# Altium schematic sheet 热网系统_采样板.SchDoc(热网系统_采样板)
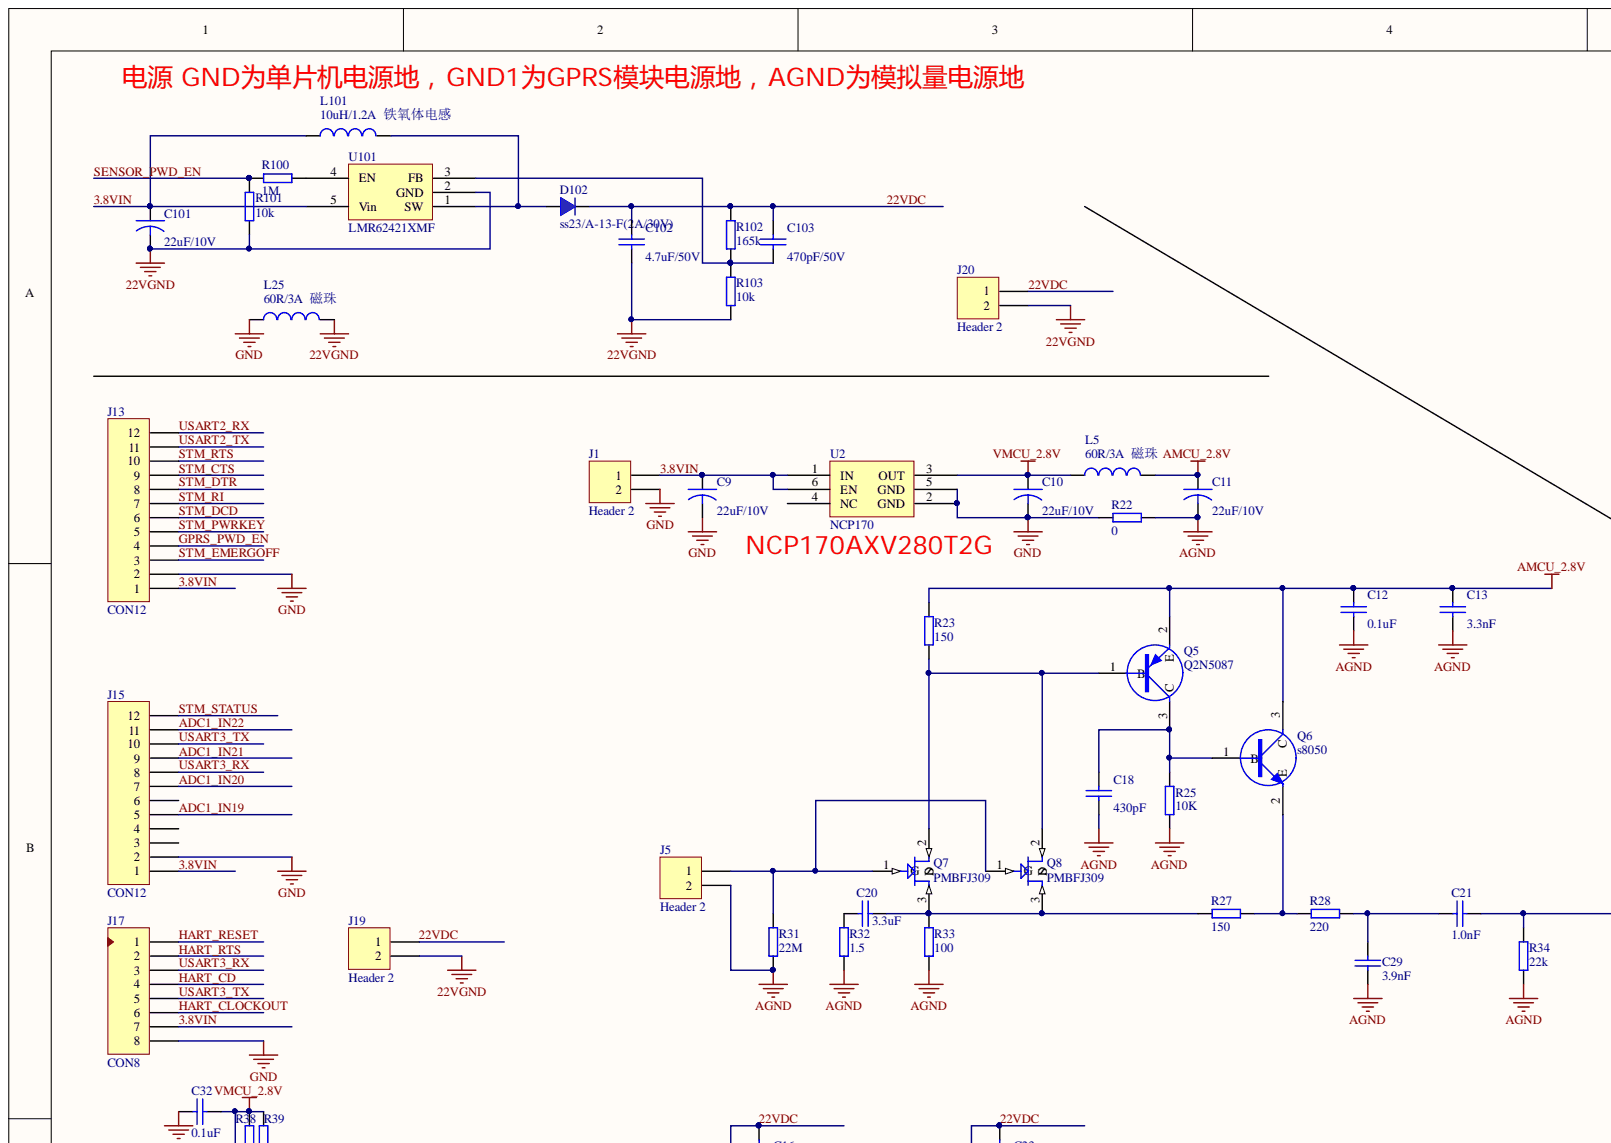
[diagram p0: 热网系统_采样板.SchDoc, top left]
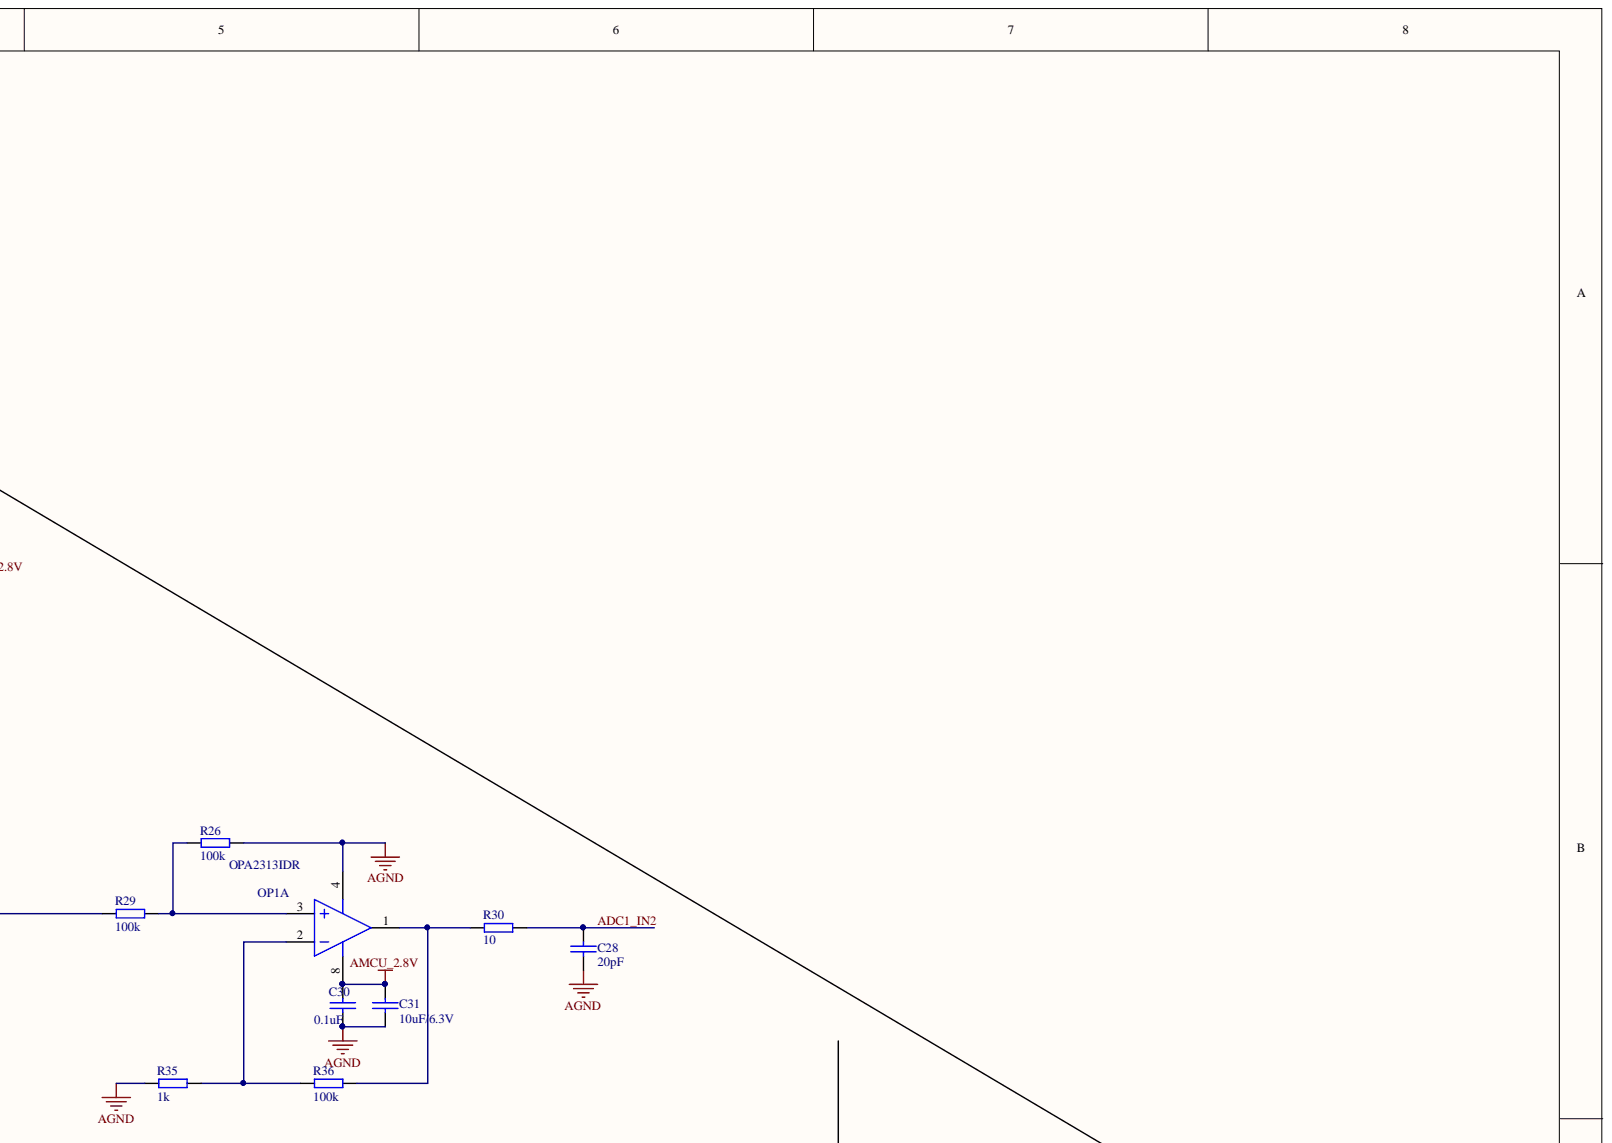
[diagram p1: 热网系统_采样板.SchDoc, top right]
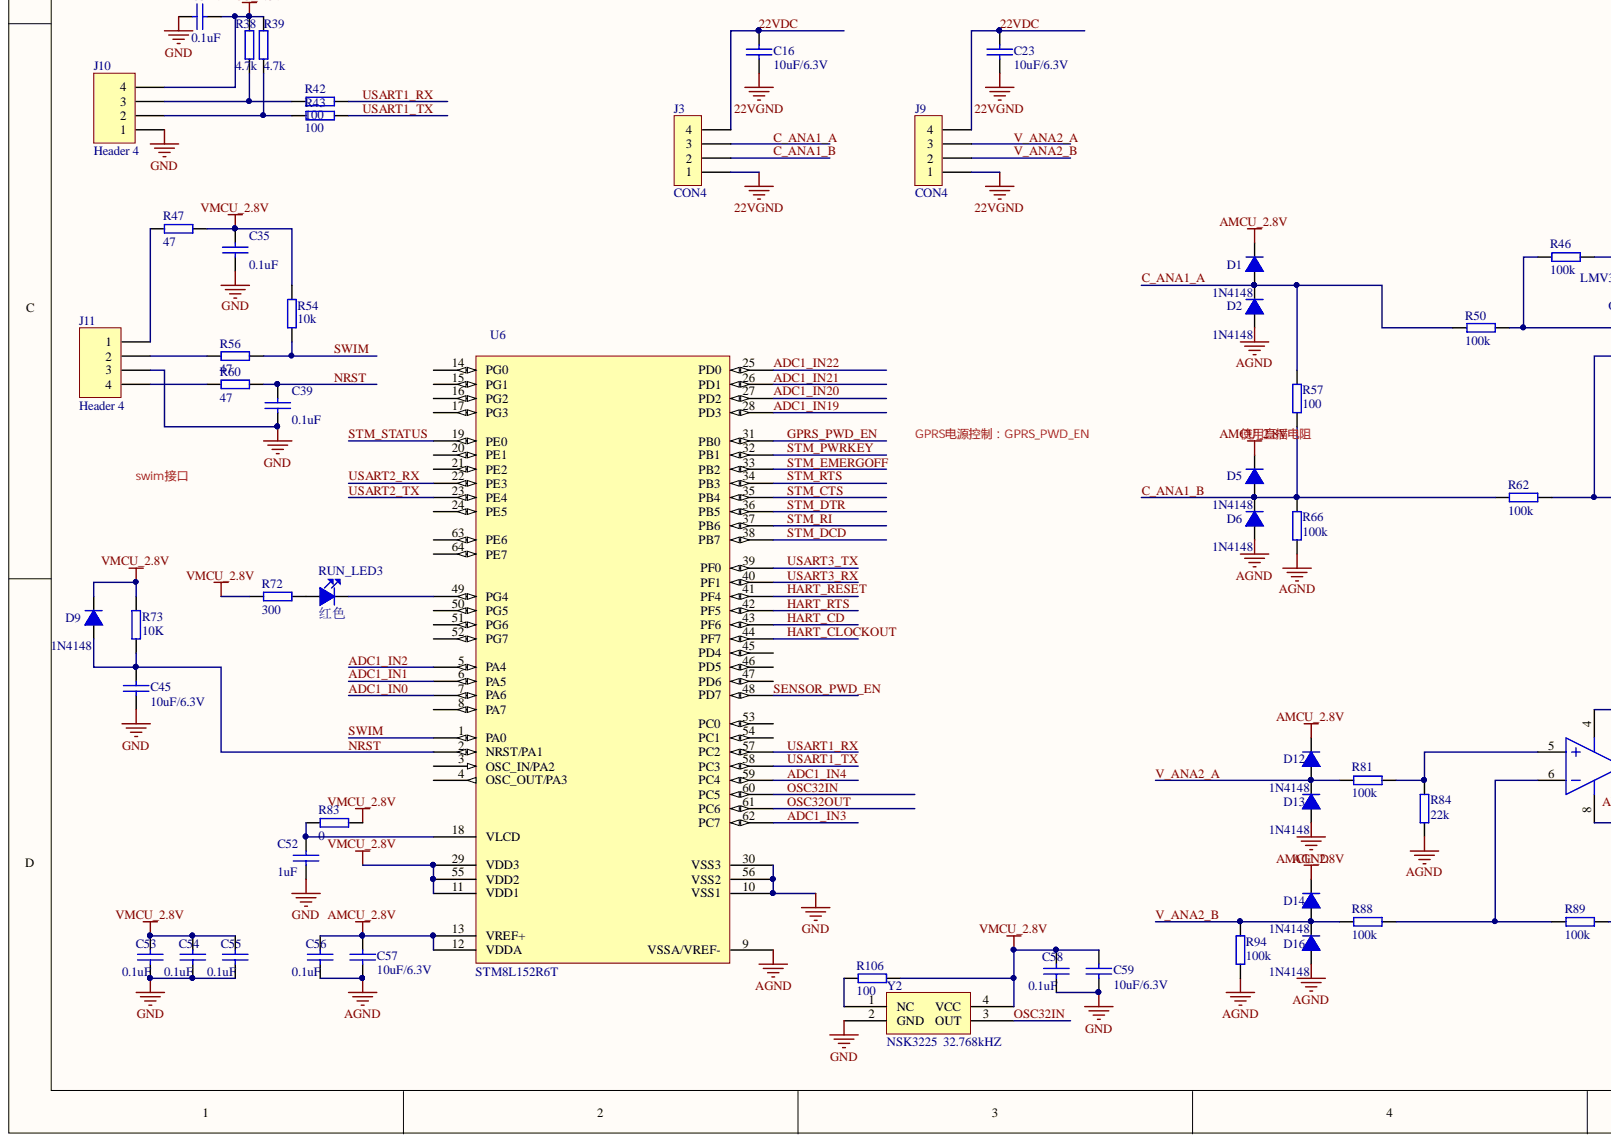
[diagram p2: 热网系统_采样板.SchDoc, bottom left]
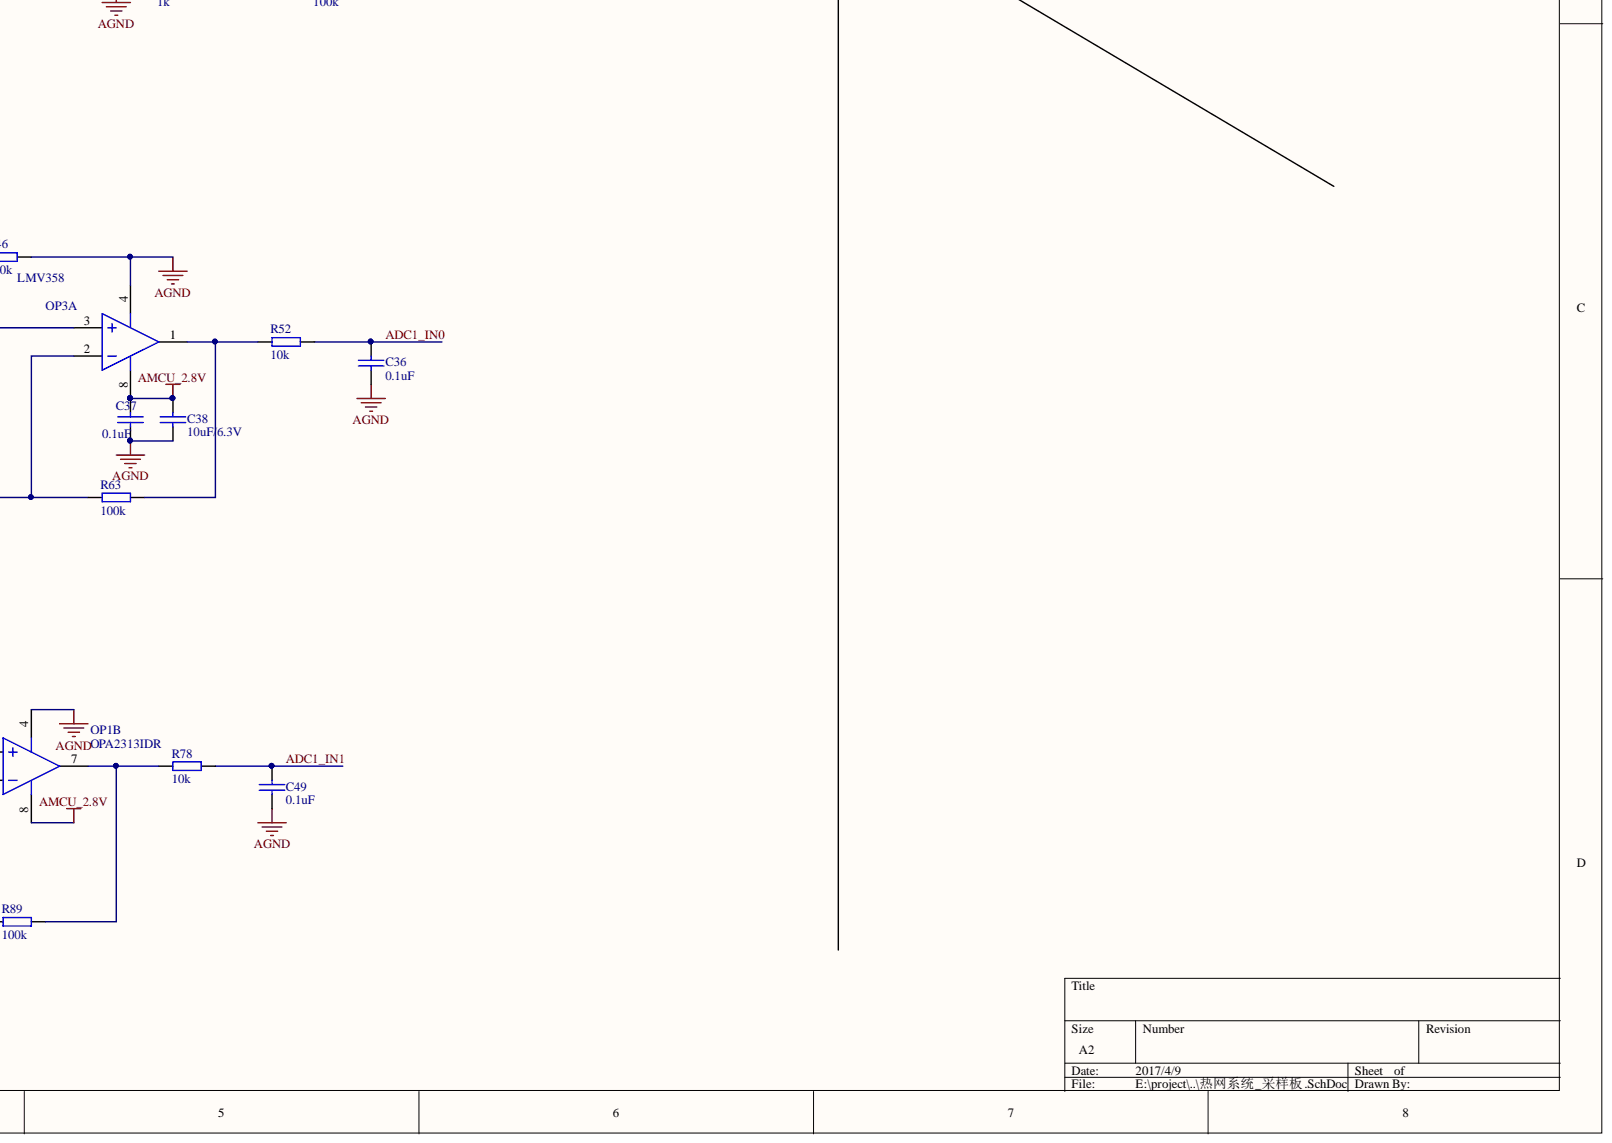
[diagram p3: 热网系统_采样板.SchDoc, bottom right]

## Parts
C9  22uF/10V  [CAP-1206]
C10  22uF/10V  [CAP-1206]
C11  22uF/10V  [CAP-1206]
C12  0.1uF  [0603-1]
C13  3.3nF  [0603-1]
C16  10uF/6.3V  [0603-1]
C18  430pF  [0603-1]
C20  3.3uF  [0805-1]
C21  1.0nF  [0603-1]
C23  10uF/6.3V  [0603-1]
C28  20pF  [0603-1]
C29  3.9nF  [0603-1]
C30  0.1uF  [0603-1]
C31  10uF/6.3V  [0603-1]
C32  0.1uF  [0603-1]
C35  0.1uF  [0603-1]
C36  0.1uF  [0603-1]
C37  0.1uF  [0603-1]
C38  10uF/6.3V  [0603-1]
C39  0.1uF  [0603-1]
C45  10uF/6.3V  [0603-1]
C49  0.1uF  [0603-1]
C52  1uF  [0603-1]
C53  0.1uF  [0603-1]
C54  0.1uF  [0603-1]
C55  0.1uF  [0603-1]
C56  0.1uF  [0603-1]
C57  10uF/6.3V  [0603-1]
C58  0.1uF  [0603-1]
C59  10uF/6.3V  [0603-1]
C101  22uF/10V  [CAP-1206]
C102  4.7uF/50V  [1206]
C103  470pF/50V  [0603-1]
D1  1N4148  [D-1206]
D2  1N4148  [D-1206]
D5  1N4148  [D-1206]
D6  1N4148  [D-1206]
D9  1N4148  [D-1206]
D12  1N4148  [D-1206]
D13  1N4148  [D-1206]
D14  1N4148  [D-1206]
D16  1N4148  [D-1206]
D102  ss23/A-13-F(2A/30V)  [D-1206]
J1  Header 2  [HDR2X1-2.54]
J3  CON4  [HDR1X4]
J5  Header 2  [HDR2X1-2.54]
J9  CON4  [HDR1X4]
J10  Header 4  [HDR1X5]
J11  Header 4  [HDR1X5]
J13  CON12  [HDR2X6 1.27]
J15  CON12  [HDR2X6 1.27]
J17  CON8  [HDR2X4 1.27]
J19  Header 2  [HDR1X2 1.27]
J20  Header 2  [HDR2X1-2.54]
L5  60R/3A  磁珠  [1206]
L25  60R/3A  磁珠  [1206]
L101  10uH/1.2A  铁氧体电感  [CD54]
OP1  OPA2313IDR  [SOIC-8]  (parts A,B)
OP3  LMV358  [SOIC-8]  (part A)
Q5  Q2N5087  [SOT-23]
Q6  s8050  [SOT-23]
Q7  PMBFJ309  [SOT-23-2]
Q8  PMBFJ309  [SOT-23-2]
R22  0  [0603-1]
R23  150  [0603-1]
R25  10K  [0603-1]
R26  100k  [0603-1]
R27  150  [0603-1]
R28  220  [0603-1]
R29  100k  [0603-1]
R30  10  [0603-1]
R31  22M  [0603-1]
R32  1.5  [0603-1]
R33  100  [0603-1]
R34  22k  [0603-1]
R35  1k  [0603-1]
R36  100k  [0603-1]
R38  4.7k  [0603-1]
R39  4.7k  [0603-1]
R42  100  [0603-1]
R43  100  [0603-1]
R46  100k  [0603-1]
R47  47  [0603-1]
R50  100k  [0603-1]
R52  10k  [0603-1]
R54  10k  [0603-1]
R56  47  [0603-1]
R57  100  [1206]
R60  47  [0603-1]
R62  100k  [0603-1]
R63  100k  [0603-1]
R66  100k  [0603-1]
R72  300  [0603-1]
R73  10K  [0603-1]
R78  10k  [0603-1]
R81  100k  [0603-1]
R83  0  [0603-1]
R84  22k  [0603-1]
R88  100k  [0603-1]
R89  100k  [0603-1]
R94  100k  [0603-1]
R100  1M  [0603-1]
R101  10k  [0603-1]
R102  165k  [0603-1]
R103  10k  [0603-1]
R106  100  [0603-1]
RUN_LED3  红色  [LED-0805]
U2  NCP170  [SOT-563]
U6  STM8L152R6T  [LQFP-64]
U101  LMR62421XMF  [SOT23-5]
Y2  NSK3225  32.768kHZ  [X-3225]

## Nets
22VGND: C16.2 C23.2 C101.2 C102.2 J3.1 J9.1 J19.2 J20.2 L25.2 R101.2 R103.2 U101.2(GND)
AGND: C11.2 C12.2 C13.2 C18.2 C28.2 C29.1 C30.2 C31.2 C36.2 C37.2 C38.2 C49.2 C56.2 C57.2 D2.1 D6.1 D13.1 D16.1 J5.2 OP1.4 OP3.4 R22.1 R25.2 R26.1 R31.2 R32.2 R33.2 R34.1 R35.2 R46.1 R66.2 R84.1 R94.2 U6.9(VSSA/VREF-)
AMCU_2.8V: C11.1 C12.1 C13.1 C30.1 C31.1 C37.1 C38.1 C56.1 C57.1 D1.2 D5.2 D12.2 D14.2 L5.2 OP1.8 OP3.8 Q5.2 Q6.3 R23.1 U6.12(VDDA) U6.13(VREF+)
GND: C9.2 C10.2 C32.2 C35.2 C39.2 C45.2 C52.2 C53.2 C54.2 C55.2 C58.2 C59.2 J1.2 J10.1 J11.3 J13.2 J15.2 J17.8 L25.1 R22.2 U2.2(GND) U2.5(GND) U6.10(VSS1) U6.30(VSS3) U6.56(VSS2) Y2.2(GND)
VMCU_2.8V: C10.1 C32.1 C35.1 C53.1 C54.1 C55.1 C58.1 C59.1 D9.2 J10.4 L5.1 R38.1 R39.1 R47.1 R54.1 R72.1 R73.2 R83.2 R106.2 U2.3(OUT) U6.11(VDD1) U6.29(VDD3) U6.55(VDD2) Y2.4(VCC)
3.8VIN: C9.1 C101.1 J1.1 J13.1 J15.1 J17.7 L101.1 U2.1(IN) U2.6(EN) U101.5(Vin)
22VDC: C16.1 C23.1 C102.1 C103.1 D102.2 J3.4 J9.4 J19.1 J20.1 R102.1
ADC1_IN0: C36.1 R52.1 U6.7(PA6)
ADC1_IN1: C49.1 R78.1 U6.6(PA5)
ADC1_IN2: C28.1 R30.1 U6.5(PA4)
ADC1_IN3: U6.62(PC7)
ADC1_IN4: U6.59(PC4)
ADC1_IN19: J15.5 U6.28(PD3)
ADC1_IN20: J15.7 U6.27(PD2)
ADC1_IN21: J15.9 U6.26(PD1)
ADC1_IN22: J15.11 U6.25(PD0)
C_ANA1_A: D1.1 D2.2 J3.3 R50.2 R57.1
C_ANA1_B: D5.1 D6.2 J3.2 R57.2 R62.2 R66.1
GPRS_PWD_EN: J13.4 U6.31(PB0)
HART_CD: J17.4 U6.43(PF6)
HART_CLOCKOUT: J17.6 U6.44(PF7)
HART_RESET: J17.1 U6.41(PF4)
HART_RTS: J17.2 U6.42(PF5)
NRST: C39.1 C45.1 D9.1 R60.1 R73.1 U6.2(NRST/PA1)
OSC32IN: U6.60(PC5) Y2.3(OUT)
OSC32OUT: U6.61(PC6)
SENSOR_PWD_EN: R100.2 R101.1 U6.48(PD7)
STM_CTS: J13.9 U6.35(PB4)
STM_DCD: J13.6 U6.38(PB7)
STM_DTR: J13.8 U6.36(PB5)
STM_EMERGOFF: J13.3 U6.33(PB2)
STM_PWRKEY: J13.5 U6.32(PB1)
STM_RI: J13.7 U6.37(PB6)
STM_RTS: J13.10 U6.34(PB3)
STM_STATUS: J15.12 U6.19(PE0)
SWIM: R54.2 R56.1 U6.1(PA0)
USART1_RX: R42.1 U6.57(PC2)
USART1_TX: R43.1 U6.58(PC3)
USART2_RX: J13.12 U6.22(PE3)
USART2_TX: J13.11 U6.23(PE4)
USART3_RX: J15.8 J17.3 U6.40(PF1)
USART3_TX: J15.10 J17.5 U6.39(PF0)
V_ANA2_A: D12.1 D13.2 J9.3 R81.2
V_ANA2_B: D14.1 D16.2 J9.2 R88.2 R94.1
NetC18_1: C18.1 Q5.3 Q6.1 R25.1
NetC20_1: C20.1 Q7.3 Q8.3 R27.1 R33.1
NetC20_2: C20.2 R32.1
NetC21_1: C21.1 C29.2 R28.2
NetC21_2: C21.2 R29.2 R34.2
NetC52_1: C52.1 R83.1 U6.18(VLCD)
NetC103_2: C103.2 R102.2 R103.1 U101.3(FB)
NetD102_1: D102.1 L101.2 U101.1(SW)
NetJ5_1: J5.1 Q7.1 Q8.1 R31.1
NetJ10_2: J10.2 R39.2 R43.2
NetJ10_3: J10.3 R38.2 R42.2
NetJ11_1: J11.1 R47.2
NetJ11_2: J11.2 R56.2
NetJ11_4: J11.4 R60.2
NetOP1_1: OP1.1 R30.2 R36.1
NetOP1_2: OP1.2 R35.1 R36.2
NetOP1_3: OP1.3 R26.2 R29.1
NetOP1_5: OP1.5 R81.1 R84.2
NetOP1_6: OP1.6 R88.1 R89.2
NetOP1_7: OP1.7 R78.2 R89.1
NetOP3_1: OP3.1 R52.2 R63.1
NetOP3_2: OP3.2 R62.1 R63.2
NetOP3_3: OP3.3 R46.2 R50.1
NetQ5_1: Q5.1 Q7.2 Q8.2 R23.2
NetQ6_2: Q6.2 R27.2 R28.1
NetR72_2: R72.2 RUN_LED3.1
NetR100_1: R100.1 U101.4(EN)
NetR106_1: R106.1 Y2.1(NC)
NetRUN_LED3_2: RUN_LED3.2 U6.49(PG4)

Not connected: J15 3 4 6; U2 4(NC); U6 3(OSC_IN/PA2) 4(OSC_OUT/PA3) 8(PA7) 14(PG0) 15(PG1) 16(PG2) 17(PG3) 20(PE1) 21(PE2) 24(PE5) 45(PD4) 46(PD5) 47(PD6) 50(PG5) 51(PG6) 52(PG7) 53(PC0) 54(PC1) 63(PE6) 64(PE7)

## Library data of the parts
C9-C11 C101 = CAPACITOR: Component Kind: Standard; Description: Capacitor; Library Name: JEC_PV_Devices.SchLib
C12 C13 C16 C18 C20 C21 C23 C28-C32 C35-C39 C45 C49 C52-C59 C102 C103 = CAP: Capacitor; Component Kind: Standard
  C28 C36: Library Name: 杂元件库.SCHLIB
  C12 C13 C18 C20 C21 C29 C30 C35 C37 C39 C52-C56 C58 C102 C103: Library Name: JEC_PV_Devices.SchLib
  C16 C23 C31 C32 C38 C45 C49 C57 C59: Library Name: ZJXU_EE_Devices.SchLib
D1 D2 D5 D6 D9 D12-D14 D16 = DIODE: 一般二极管; Component Kind: Standard; Library Name: ZJXU_EE_Devices.SchLib
D102 = DIODE: B230/A; Component Kind: Standard; Library Name: ZJXU_EE_Devices.SchLib
J1 J5 J19 J20 = Header 2: Header, 2-Pin; Component Kind: Standard; LatestRevisionDate: 17-Jul-2002; LatestRevisionNote: Re-released for DXP Platform.; Library Name: Miscellaneous Connectors.IntLib; Publisher: Altium Limited
J3 J9 = CON4: Connector; Component Kind: Standard; Library Name: 杂元件库.SCHLIB
J10 J11 = Header 4: Header, 4-Pin; Component Kind: Standard; LatestRevisionDate: 17-Jul-2002; LatestRevisionNote: Re-released for DXP Platform.; Library Name: Miscellaneous Connectors.IntLib; Signal Integrity: Connector; Publisher: Altium Limited
J13 J15 = CON12: Connector; Component Kind: Standard; Library Name: 杂元件库.SCHLIB
J17 = Header 8: 接插件; Component Kind: Standard; LatestRevisionDate: 17-Jul-2002; LatestRevisionNote: Re-released for DXP Platform.; Library Name: HDR_fszhang.SchLib; Publisher: Altium Limited
L5 L25 L101 = INDUCTOR: 磁珠; Component Kind: Standard; Library Name: JEC_PV_Devices.SchLib
OP3 = OPA2313IDR: Component Kind: Standard; Library Name: prevate.SchLib
Q5 = PNP: PNP Transistor; Component Kind: Standard; Library Name: 杂元件库.SCHLIB
Q6 = NPN: NPN Transistor; Component Kind: Standard; Library Name: 杂元件库.SCHLIB
Q7 Q8 = JFET N: Component Kind: Standard; Library Name: 杂元件库.SCHLIB
R22 R23 R25-R36 R38 R39 R42 R43 R46 R47 R50 R52 R54 R56 R57 R60 R62 R63 R66 R72 R73 R78 R81 R83 R84 R88 R89 R94 R100-R103 R106 = RES2: Component Kind: Standard; Library Name: ZJXU_EE_Devices.SchLib
RUN_LED3 = LED: Component Kind: Standard; Library Name: ZJXU_EE_Devices.SchLib
U6 = STM8L152R6: Component Kind: Standard; Library Name: ST Microcontroller 8-Bit STM8.SchLib
OP1 = OPA2313IDR: Component Kind: Standard; Library Name: prevate.SchLib
U2 = NCP170: Component Kind: Standard; Library Name: prevate.SchLib
U101 = LMR62421XMF: Component Kind: Standard; Library Name: prevate.SchLib
Y2 = NSK3225  32.768kHZ: Component Kind: Standard; Library Name: prevate.SchLib
